# Altium schematic sheet LCD.SchDoc(LCD)
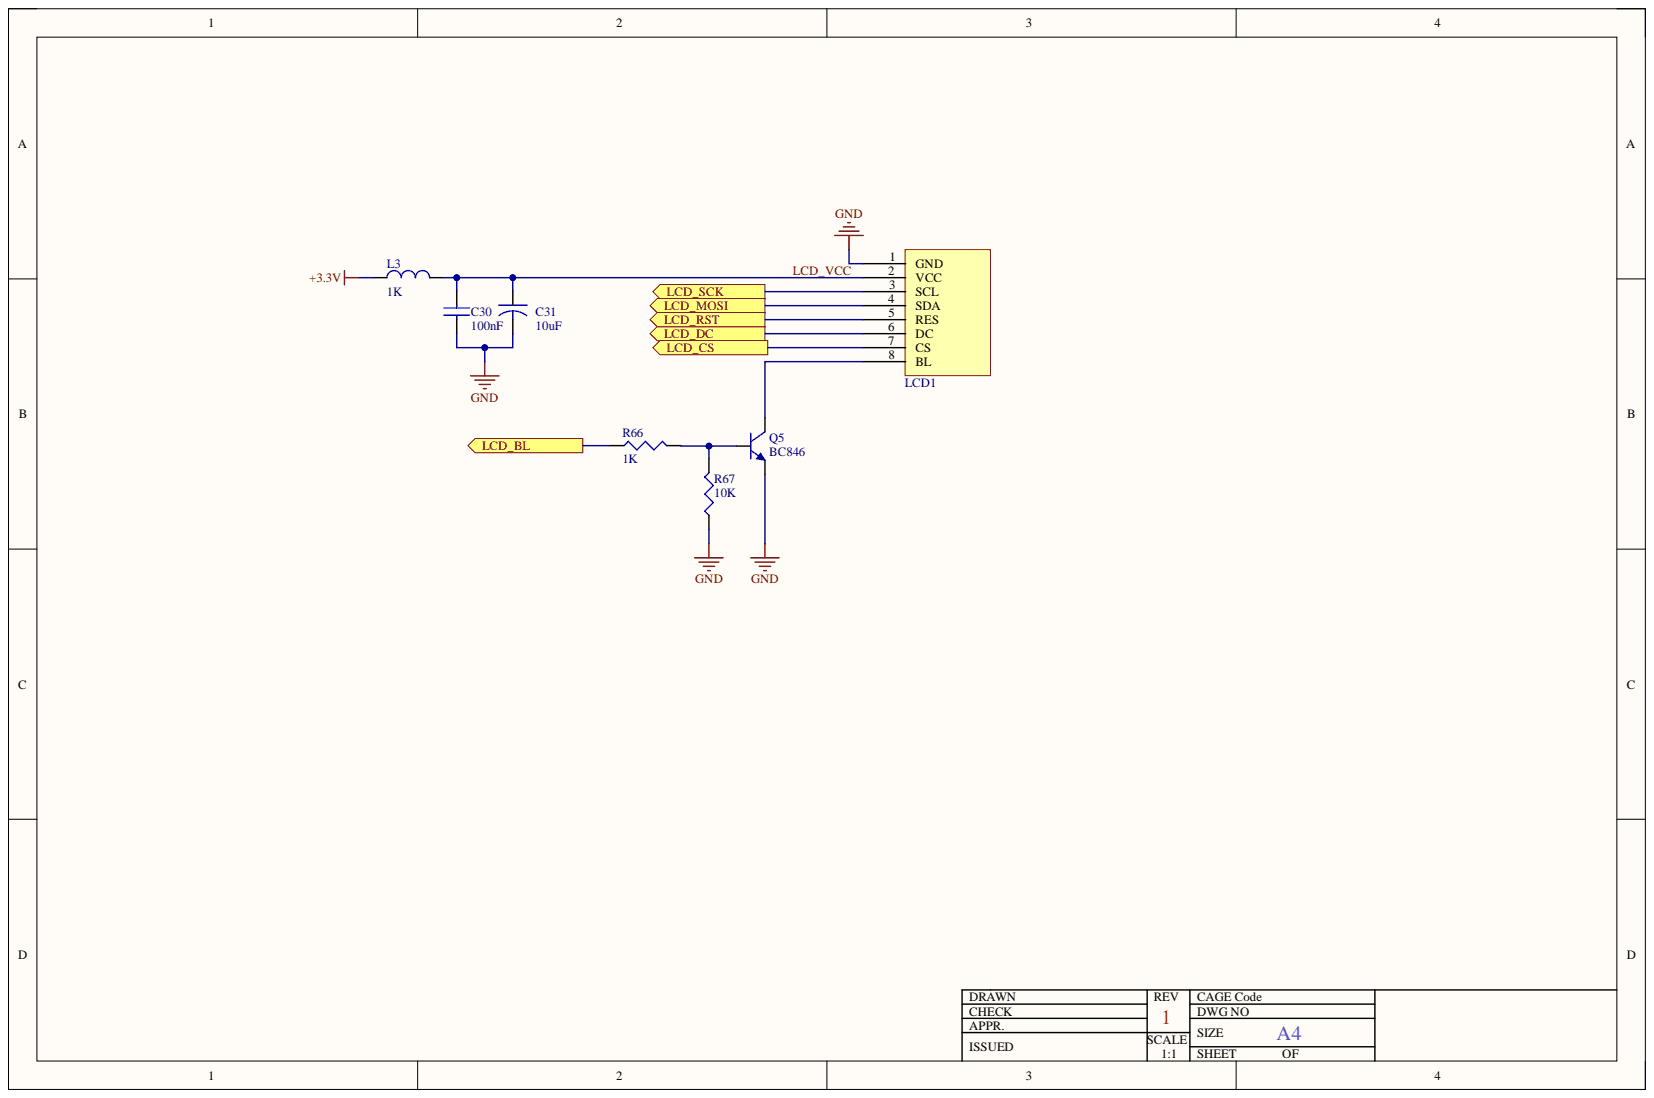
[diagram p0: LCD.SchDoc]

## Parts
C30  value 100nF  [0805 SMD Ceramic Capacitor]  (hidden comment: C0805C104)
C31  value 10uF  [A Case]  (hidden comment: T491A106)
L3  value 1K  [0805 Inductor]  (hidden comment: 0805Ferrite)
LCD1  ST7789_1.9_170x320  [ST7789_1.9_170x320]  (value not drawn)
Q5  BC846  [SOT23]
R66  value 1K  [0805 Resistor]  (hidden comment: 0805_1K)
R67  value 10K  [0805 Resistor]  (hidden comment: 0805_10K)

## Ports
LCD_BL, LCD_CS, LCD_DC, LCD_MOSI, LCD_RST, LCD_SCK

## Nets
+3.3V: L3.1
GND: C30.2 C31.2 LCD1.1(GND) Q5.2 R67.1
LCD_BL: R66.1 ; port LCD_BL
LCD_CS: LCD1.7(CS) ; port LCD_CS
LCD_DC: LCD1.6(DC) ; port LCD_DC
LCD_MOSI: LCD1.4(SDA) ; port LCD_MOSI
LCD_RST: LCD1.5(RES) ; port LCD_RST
LCD_SCK: LCD1.3(SCL) ; port LCD_SCK
LCD_VCC: C30.1 C31.1 L3.2 LCD1.2(VCC)
NetLCD1_8: LCD1.8(BL) Q5.3
NetQ5_1: Q5.1 R66.2 R67.2

## Library data of the parts
C30 = C0805C104: Manufacturer: KEMET; Manufacturer Code: C0805C104J5RACTU; Voltage: 50V; Component Kind: Standard; Farnell Code: 1414663; Library Name: Capacitors.SchLib; Package: 0805; Unit Price: 0,06 €
C31 = T491A106: Manufacturer: KEMET; Manufacturer Code: T491A106K006AT; Voltage: 6V3; Component Kind: Standard; Farnell Code: 1457412; Library Name: Capacitors.SchLib; Unit Price: 0,143 €
L3 = 0805Ferrite: Manufacturer: TDK; Manufacturer Code: MMZ2012R102A; Current: 500mA; Component Kind: Standard; Farnell Code: 1669719; Library Name: FerriteBead.SchLib; Unit Price: 0,059 €
LCD1 = ST7789_1.9_170x320: ST7789 1.9" TFT IPS 170x320; Component Kind: Standard; Library Name: ST7789_19_170x320.SCHLIB; Unit Price: 4 $; Value: ST7789
Q5 = BC846: Manufacturer: NXP Semiconductors; Voltage: 65V; Component Kind: Standard; Farnell Code: 1081227; Library Name: BC846.SchLib; Manufacturer Code: BC846; Unit Price: 0,049 €; Value: BC846
R66 = 0805_1K: Manufacturer: MULTICOMP; Manufacturer Code: MC01W080511K; Tolerance: ±1%; Component Kind: Standard; Farnell Code: 9332383; Library Name: Resistors.SchLib; Unit Price: 0,03 €
R67 = 0805_10K: Manufacturer: MULTICOMP; Manufacturer Code: MC 0.1W 0805 1% 10K; Tolerance: ±1%; Component Kind: Standard; Farnell Code: 9332391; Library Name: Resistors.SchLib; Unit Price: 0,03 €
